# Altium schematic sheet IMU.SchDoc(IMU)
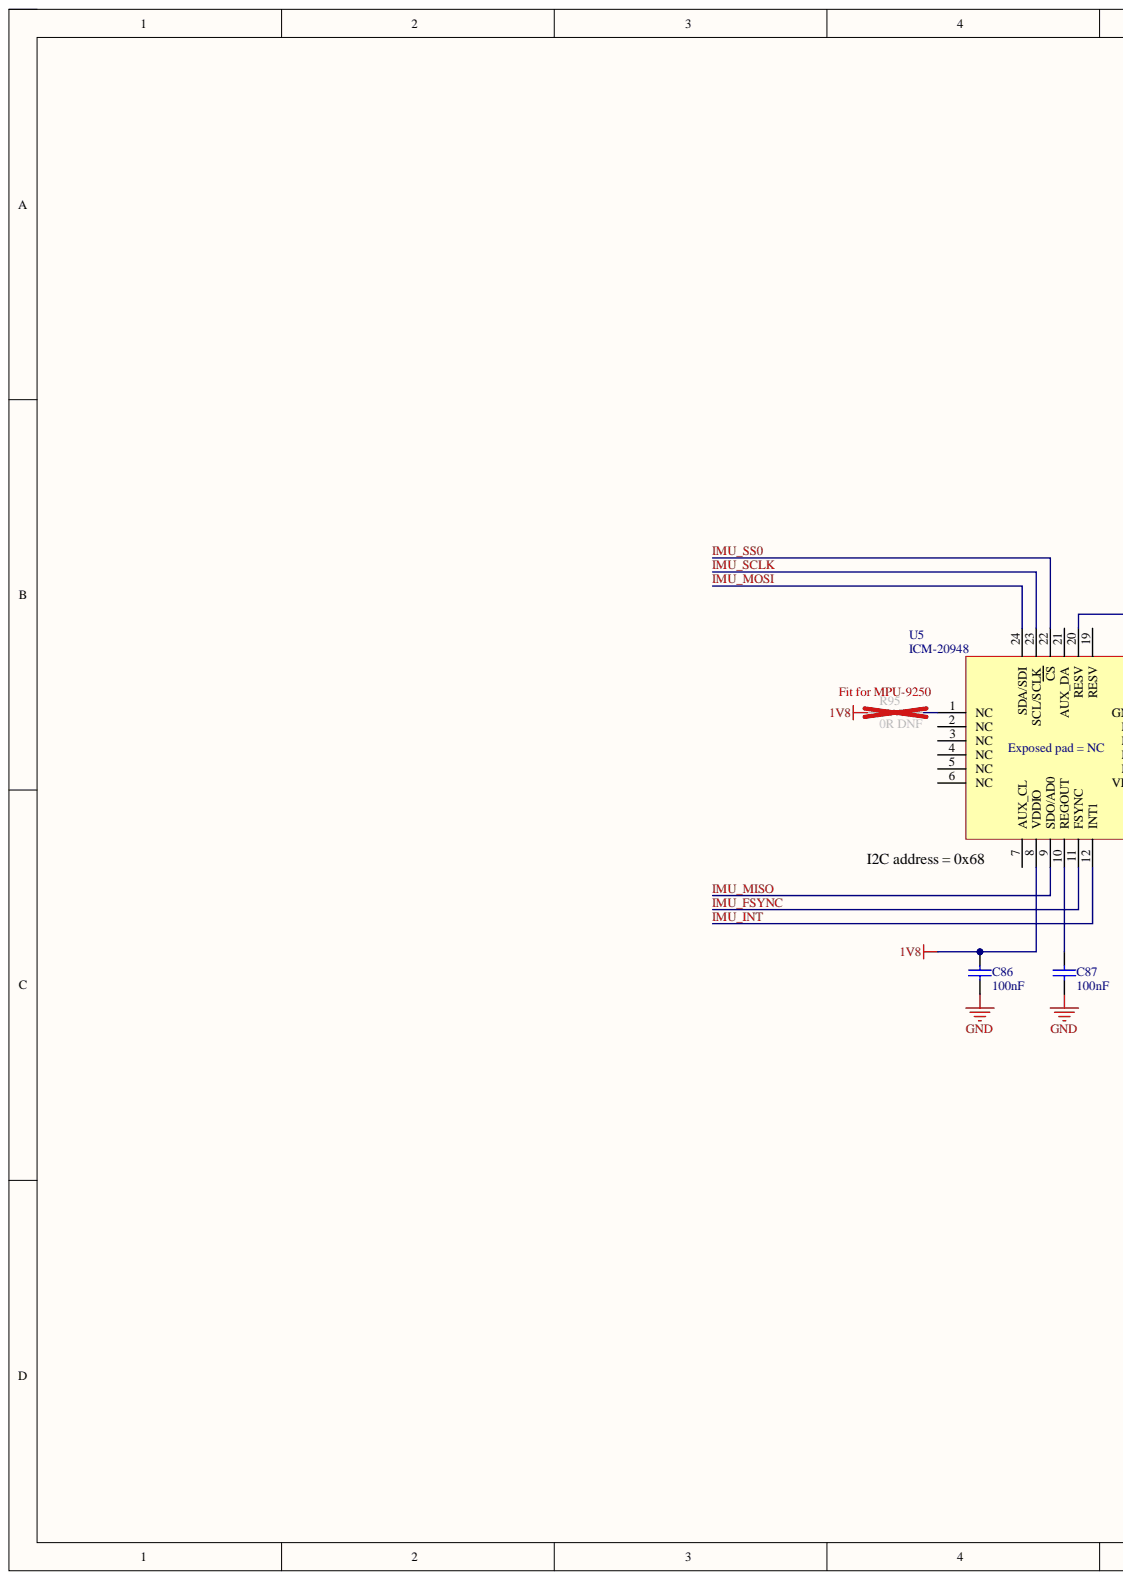
[diagram p0: IMU.SchDoc, left]
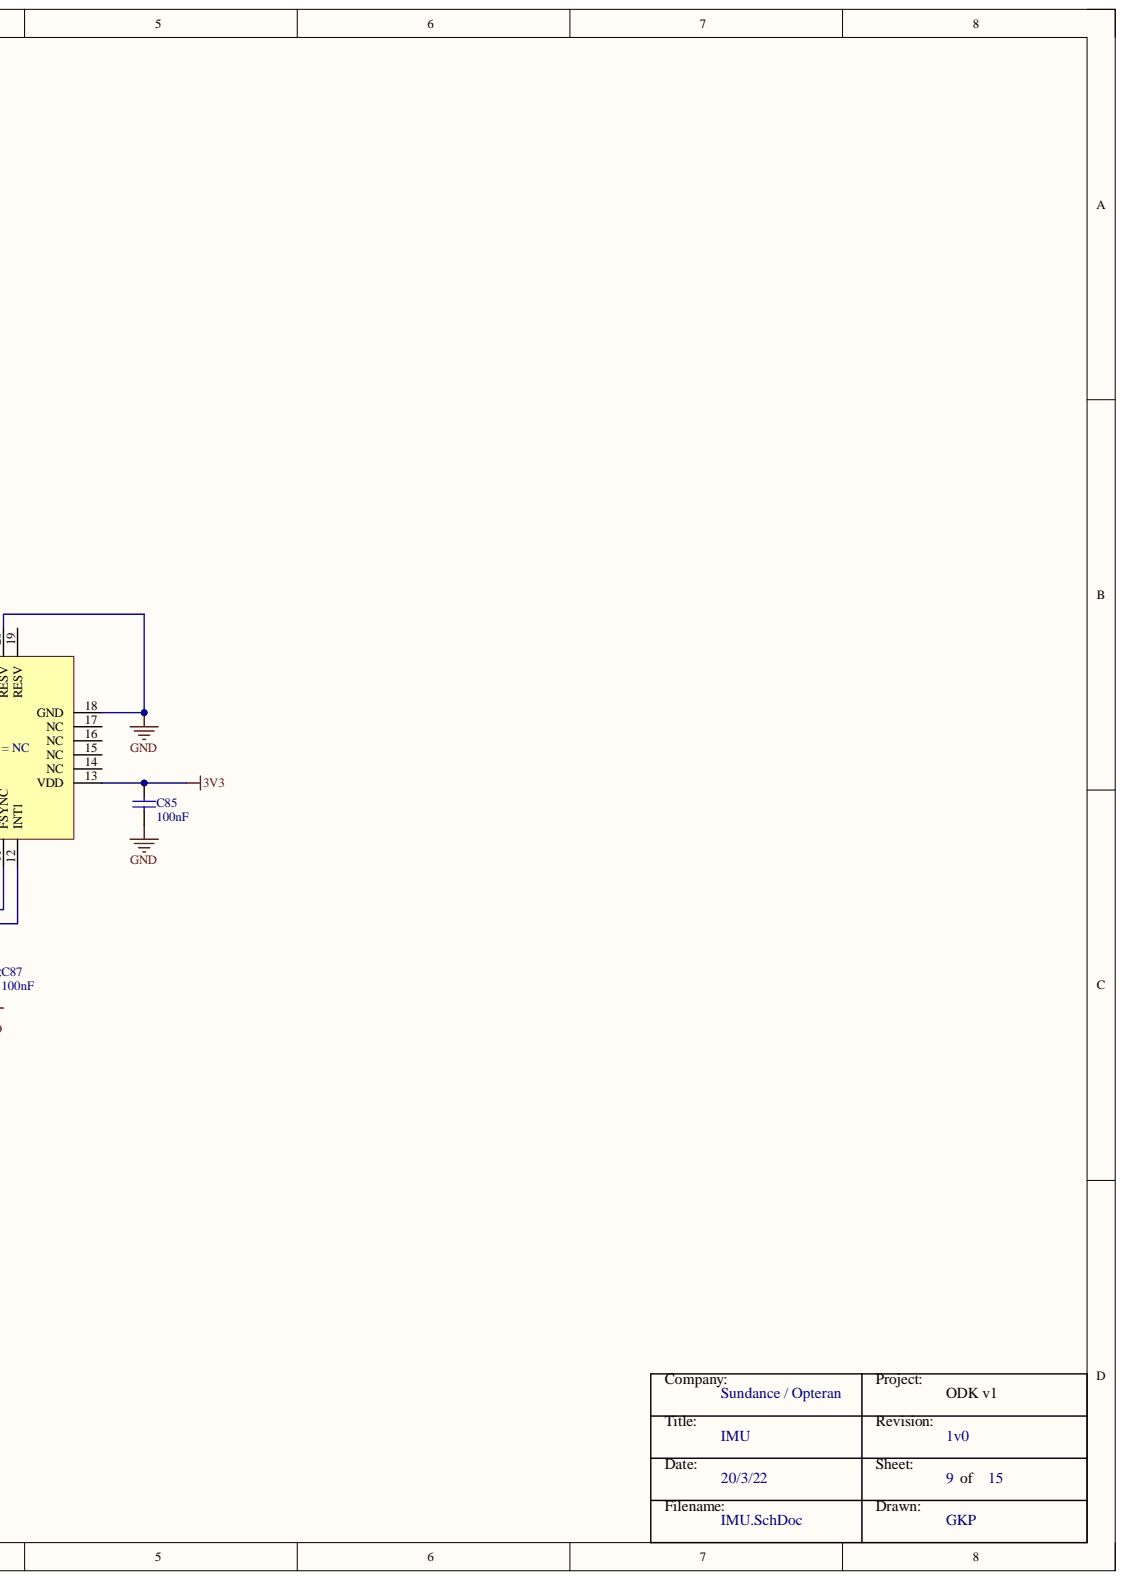
[diagram p1: IMU.SchDoc, right]

## Parts
C85  100nF  [0201panERJ]
C86  100nF  [0201panERJ]
C87  100nF  [0201panERJ]
R95  0R DNF  [RES0201]
U5  ICM-20948  Manufacturer Part Number 2: MPU-9250  [QFN40P300X300X105-25N-D]

## Nets
1V8: C86.2 R95.2 U5.8(VDDIO)
3V3: C85.2 U5.13(VDD)
GND: C85.1 C86.1 C87.1 U5.18(GND) U5.20(RESV)
IMU_FSYNC: U5.11(FSYNC)
IMU_INT: U5.12(INT1)
IMU_MISO: U5.9(SDO/AD0)
IMU_MOSI: U5.24(SDA/SDI)
IMU_SCLK: U5.23(SCL/SCLK)
IMU_SS0: U5.22(CS)
NetC87_2: C87.2 U5.10(REGOUT)
NetR95_1: R95.1 U5.1(NC)

Not connected: U5 2(NC) 3(NC) 4(NC) 5(NC) 6(NC) 7(AUX_CL) 14(NC) 15(NC) 16(NC) 17(NC) 19(RESV) 21(AUX_DA)

## Library data of the parts
C85-C87 = Cap0201: 100nF 0201 6V3 X5R; Case-EIA: 0402; Component Kind: Standard; Library Name: Schematic Components.SchLib; Max Thickness: 0.6 mm; Technology: SMT
R95 = Res0201: 0R 0201 1/6W; Value: ?k; Component Kind: Standard; Library Name: Passive LCR.SchLib
U5 = ICM-20948: Accelerometer, Gyroscope, Magnetometer, 9 Axis Sensor I²C, SPI Output; Manufacturer 1: TDK InvenSense; Component Kind: Standard; Library Name: Schematic Components.SchLib; Manufacturer Part Number 1: ICM-20948; Supplier 1: Digi-Key; Supplier Part Number 1: 1428-1123-2-ND
